# Altium schematic sheet My4G.SchDoc
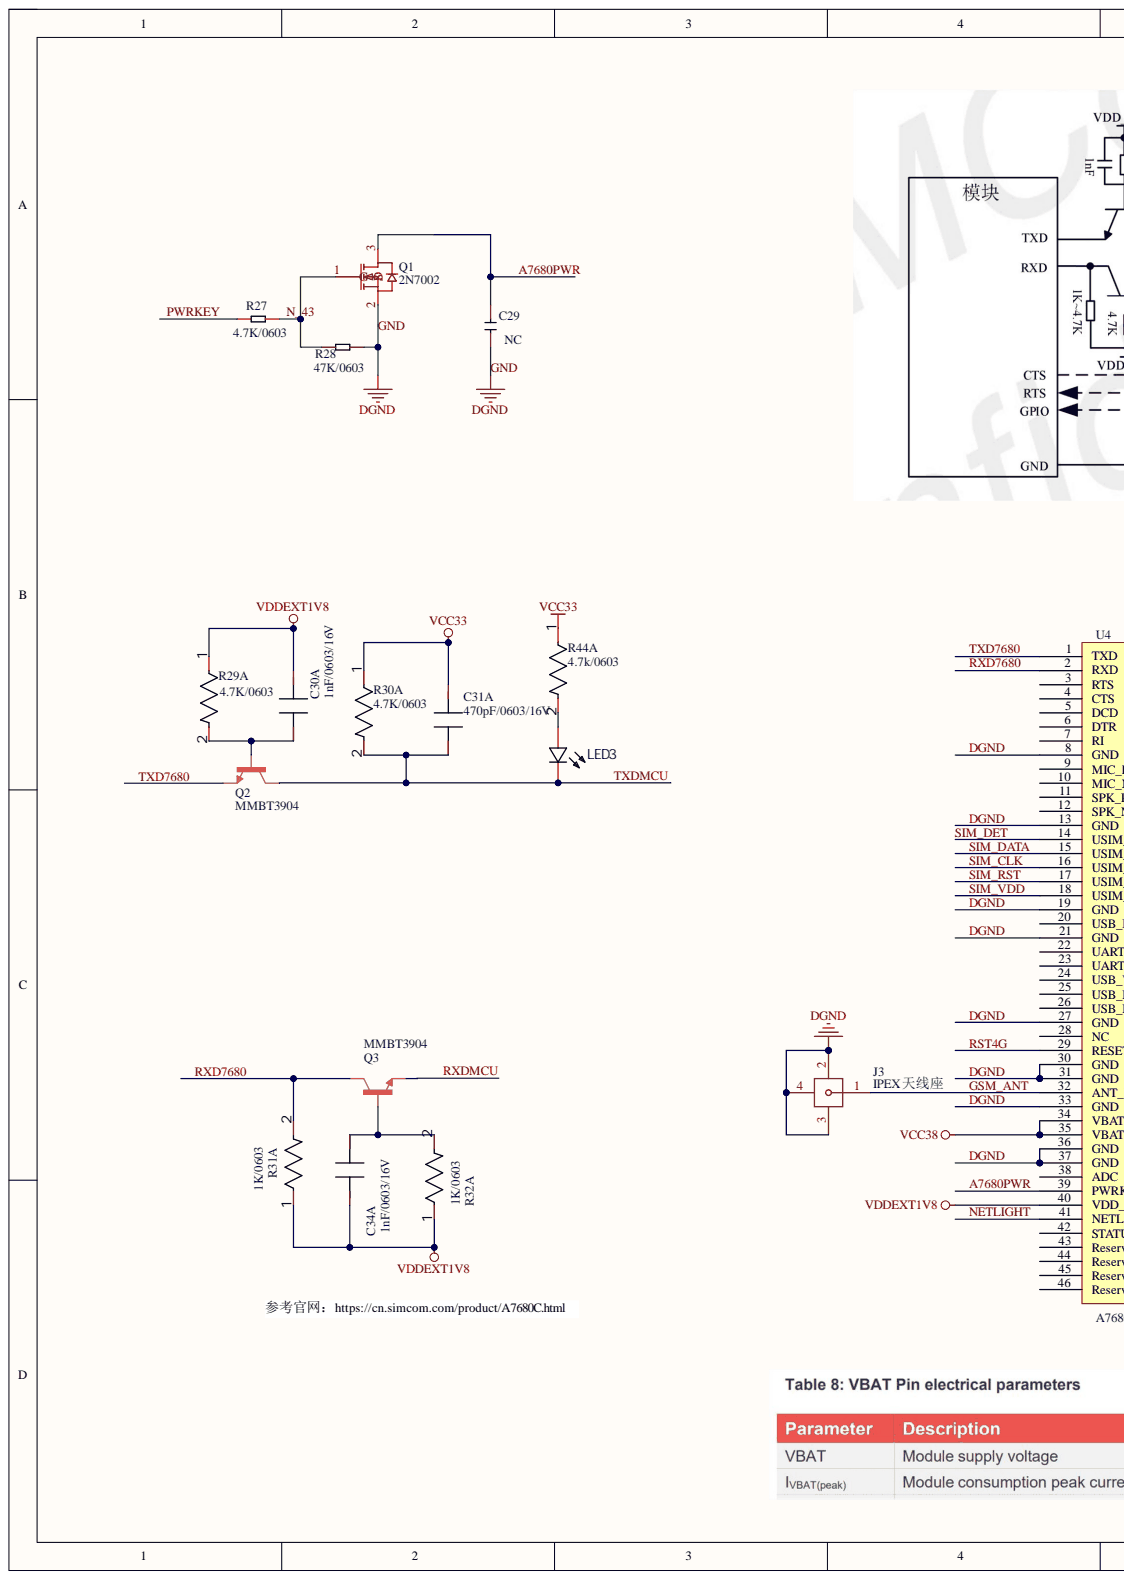
[diagram p0: My4G.SchDoc, left]
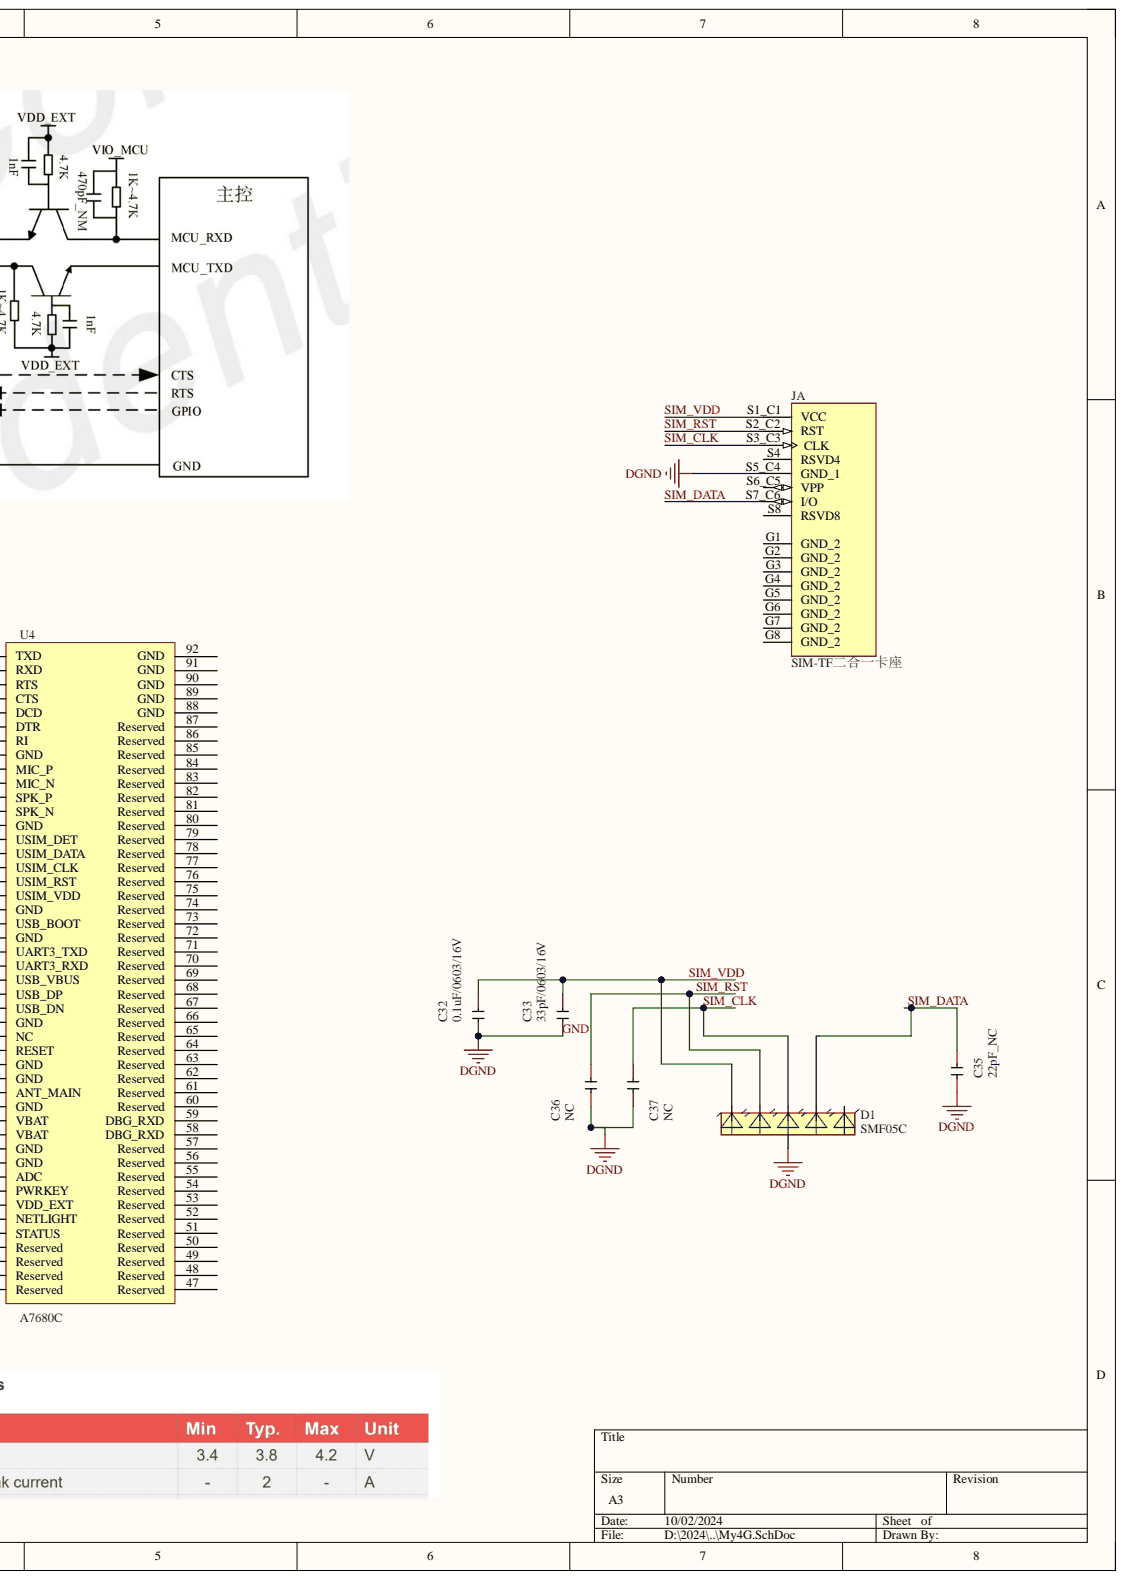
[diagram p1: My4G.SchDoc, right]

## Parts
C29  NC  [CAP0603]
C30  1nF/0603/16V  [CAP0603]  (part A)
C31  470pF/0603/16V  [CAP0603]  (part A)
C32  0.1uF/0603/16V  [CAP0603]
C33  33pF/0603/16V  [CAP0603]
C34  1nF/0603/16V  [CAP0603]  (part A)
C35  Name: 22pF_NC  [CAP0603]  (hidden comment: NC)
C36  NC  [CAP0603]
C37  NC  [CAP0603]
D1  SMF05C  [SMF05C-SC706]
J  SIM-TF二合一卡座  [MOLEX_104642-1610]  (part A)
J3  IPEX天线座  [IPEX-SMD_HC-RF-IPEX0303-01]
LED3  19-217/GHC-YR1S2/3T  [LED_0603_KA]  (value not drawn)
Q1  2N7002  [SOT-23_2N7002]
Q2  MMBT3904  [TRANS_SS8050-G]
Q3  MMBT3904  [TRANS_SS8050-G]
R27  4.7K/0603  [R0603]
R28  47K/0603  [R0603]
R29  4.7K/0603  [R0603]  (part A)
R30  4.7K/0603  [R0603]  (part A)
R31  1K/0603  [R0603]  (part A)
R32  1K/0603  [R0603]  (part A)
R44  4.7k/0603  [R0603]  (part A)
U4  A7680C  [LCC-LAG_L17.6-W15.7_A7680C]

## Nets
VCC33: C31.1 R30.1 R44.1
VCC38: U4.34(VBAT) U4.35(VBAT)
VDDEXT1V8: C30.1 C34.1 R29.1 R31.1 R32.1 U4.40(VDD_EXT)
A7680PWR: C29.2 Q1.3 U4.39(PWRKEY)
DGND: C29.1 C32.1 C33.1 C35.1 C36.1 C37.1 D1.2 J.S5_C4(GND_1) J3.2 J3.3 J3.4 Q1.2 R28.1 U4.8(GND) U4.13(GND) U4.19(GND) U4.21(GND) U4.27(GND) U4.30(GND) U4.31(GND) U4.33(GND) U4.36(GND) U4.37(GND)
GSM_ANT: J3.1 U4.32(ANT_MAIN)
N_43: Q1.1 R27.1 R28.2
NETLIGHT: U4.41(NETLIGHT)
PWRKEY: R27.2
RST4G: U4.29(RESET)
RXD7680: Q3.3 R31.2 U4.2(RXD)
RXDMCU: Q3.2
SIM_CLK: C37.2 D1.4 J.S3_C3(CLK) U4.16(USIM_CLK)
SIM_DATA: C35.2 D1.5 J.S7_C6(I/O) U4.15(USIM_DATA)
SIM_DET: U4.14(USIM_DET)
SIM_RST: C36.2 D1.3 J.S2_C2(RST) U4.17(USIM_RST)
SIM_VDD: C32.2 C33.2 D1.1 J.S1_C1(VCC) U4.18(USIM_VDD)
TXD7680: Q2.2 U4.1(TXD)
TXDMCU: C31.2 LED3.2 Q2.3 R30.2
NetC30_2: C30.2 Q2.1 R29.2
NetC34_2: C34.2 Q3.1 R32.2
NetLED3_1: LED3.1 R44.2

Not connected: D1 6; J G1(GND_2) G2(GND_2) G3(GND_2) G4(GND_2) G5(GND_2) G6(GND_2) G7(GND_2) G8(GND_2) S4(RSVD4) S6_C5(VPP) S8(RSVD8); U4 3(RTS) 4(CTS) 5(DCD) 6(DTR) 7(RI) 9(MIC_P) 10(MIC_N) 11(SPK_P) 12(SPK_N) 20(USB_BOOT) 22(UART3_TXD) 23(UART3_RXD) 24(USB_VBUS) 25(USB_DP) 26(USB_DN) 28(NC) 38(ADC) 42(STATUS) 43(Reserved) 44(Reserved) 45(Reserved) 46(Reserved) 47(Reserved) 48(Reserved) 49(Reserved) 50(Reserved) 51(Reserved) 52(Reserved) 53(Reserved) 54(Reserved) 55(Reserved) 56(Reserved) 57(Reserved) 58(DBG_RXD) 59(DBG_RXD) 60(Reserved) 61(Reserved) 62(Reserved) 63(Reserved) 64(Reserved) 65(Reserved) 66(Reserved) 67(Reserved) 68(Reserved) 69(Reserved) 70(Reserved) 71(Reserved) 72(Reserved) 73(Reserved) 74(Reserved) 75(Reserved) 76(Reserved) 77(Reserved) 78(Reserved) 79(Reserved) 80(Reserved) 81(Reserved) 82(Reserved) 83(Reserved) 84(Reserved) 85(Reserved) 86(Reserved) 87(Reserved) 88(GND) 89(GND) 90(GND) 91(GND) 92(GND)

## Library data of the parts
C29 C35 C37 = Discrete_C*_-0603: Add into BOM: yes; Origin Footprint: 0603; Component Kind: Standard; Convert to PCB: yes; Device: Discrete_C*_-0603; Symbol: Discrete_C*_-0603
  C29 C37: Name: NC
C30 = CAP0603: SURFACE MOUNT CAPACITOR 0.031 X 0.061 INCHES; Manufacturer #1: IPC SM-782 STD.; Add into BOM: yes; Origin Footprint: 0603; Sim_Analog_Order: Model$; Value: 1uF/50V/0603; Component Kind: Standard; Convert to PCB: yes; Device: CAP0603; Name: CAP0603; OldName: CAP0603; PCB DECAL: 0603; Symbol: CAP0603
C31 C34 = CAP0603: SURFACE MOUNT CAPACITOR 0.031 X 0.061 INCHES; Manufacturer #1: IPC SM-782 STD.; Add into BOM: yes; Origin Footprint: 0603; Sim_Analog_Order: Model$; Value: 1uF/50V/0805; Component Kind: Standard; Convert to PCB: yes; Device: CAP0603; Name: CAP0603; OldName: CAP0603; PCB DECAL: 0603; Symbol: CAP0603
C32 = Discrete_C*_-0603: Add into BOM: yes; Name: 0.1uF; Origin Footprint: 0603; Component Kind: Standard; Convert to PCB: yes; Device: Discrete_C*_-0603; Symbol: Discrete_C*_-0603
C33 = Discrete_C*_-0603: Add into BOM: yes; Name: 33pF; Origin Footprint: 0603; Component Kind: Standard; Convert to PCB: yes; Device: Discrete_C*_-0603; Symbol: Discrete_C*_-0603
C36 = Discrete_C*_-0603: Add into BOM: yes; Name: 22pF_NC; Origin Footprint: 0603; Component Kind: Standard; Convert to PCB: yes; Device: Discrete_C*_-0603; Symbol: Discrete_C*_-0603
D1 = SMF05C: 12.5V Clamp 8A (8/20Âµs) Ipp Tvs Diode Surface Mount SC-88/SC70-6/SOT-363; Component Kind: Standard; Library Name: ChargingPile.SchLib
J = 104642-1610: 17 (16 + 1) Position Card Connector Combo - microSDâ„¢, Micro SIM Surface Mount, Right Angle Gold or Gold-Palladium; MF: Molex; Availability: Warning; Component Kind: Standard; Mouser-Purchase-URL: https://snapeda.com/shop?store=Mouser&id=501052; MP: 104642-1610; Package: None; Price: None; SnapEDA_Link: https://www.snapeda.com/parts/104642-1610/Molex/view-part/501052/?ref=snap; Library Name: ESPC6IOTALLinOne.SCHLIB
LED3 = 19-217/GHC-YR1S2/3T: Manufacturer: EVERLIGHT; Add into BOM: yes; Origin Footprint: LED0603-R-RD; Component Kind: Standard; Convert to PCB: yes; Device: 19-217/GHC-YR1S2/3T; JLCPCB Part Class: Basic Part; Manufacturer Part: 19-217/GHC-YR1S2/3T; Name: 19-217/GHC-YR1S2/3T; Supplier: LCSC; Supplier Part: C72043; Symbol: 19-217/GHC-YR1S2/3T
Q1 = 2N7002: Nmos 2N7000, 2N7002, NDS7002A; Component Kind: Standard; Library Name: ChargingPile.SchLib
Q2 Q3 = SS8050-G: Component Kind: Standard; Library Name: ChargingPile.SchLib
R27 R28 = Discrete_R*_-0603: Add into BOM: yes; Component Kind: Standard; Convert to PCB: yes; Device: Discrete_R*_-0603; Origin Footprint: R0603; Symbol: Discrete_R*_-0603
  R27: Name: 4.7K/0603
  R28: Name: 47K/0603
R29 = RES0603: SURFACE MOUNT RESISTOR 0.031 X 0.061 INCHES, 1/10W; Manufacturer #1: IPC SM-782 STD.; Add into BOM: yes; Origin Footprint: 0805; Sim_Analog_Order: Model$; Sim_Analog_Prefix: R; Value: 1K/0603; Component Kind: Standard; Convert to PCB: yes; Device: RES0603; Name: RES0603; OldName: RES0603; PCB DECAL: 0805; Symbol: RES0603
R30 R32 R44 = RES0603: SURFACE MOUNT RESISTOR 0.031 X 0.061 INCHES, 1/10W; Manufacturer #1: IPC SM-782 STD.; Add into BOM: yes; Origin Footprint: 0805; Sim_Analog_Order: Model$; Sim_Analog_Prefix: R; Value: 1K/0805; Component Kind: Standard; Convert to PCB: yes; Device: RES0603; Name: RES0603; OldName: RES0603; PCB DECAL: 0805; Symbol: RES0603
R31 = RES0603: SURFACE MOUNT RESISTOR 0.031 X 0.061 INCHES, 1/10W; Manufacturer #1: IPC SM-782 STD.; Add into BOM: yes; Origin Footprint: 0805; Sim_Analog_Order: Model$; Sim_Analog_Prefix: R; Component Kind: Standard; Convert to PCB: yes; Device: RES0603; Name: RES0603; OldName: RES0603; PCB DECAL: 0805; Symbol: RES0603; Value: 1K/0603
U4 = A7680C-LAAS: 4G cat1 module; Component Kind: Standard
J3 = IPEX天线座: Component Kind: Standard; Library Name: ChargingPile.SchLib
